# Altium schematic sheet adruino_io.SchDoc(adruino_io)
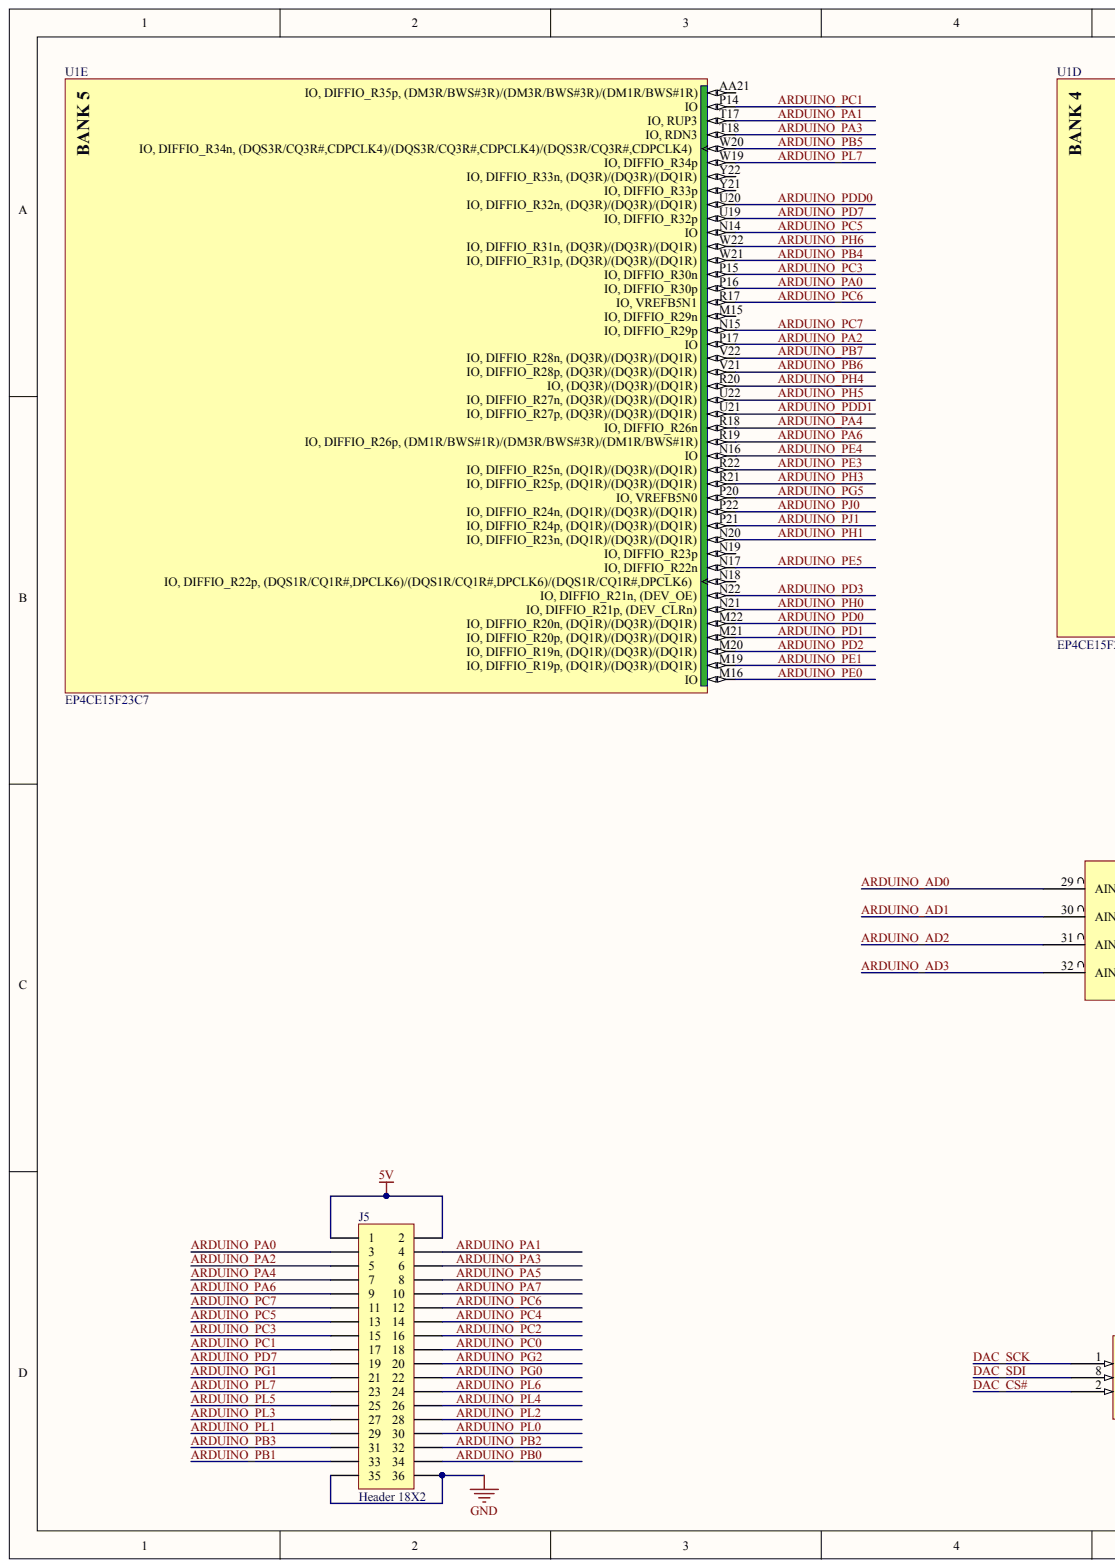
[diagram p0: adruino_io.SchDoc, left]
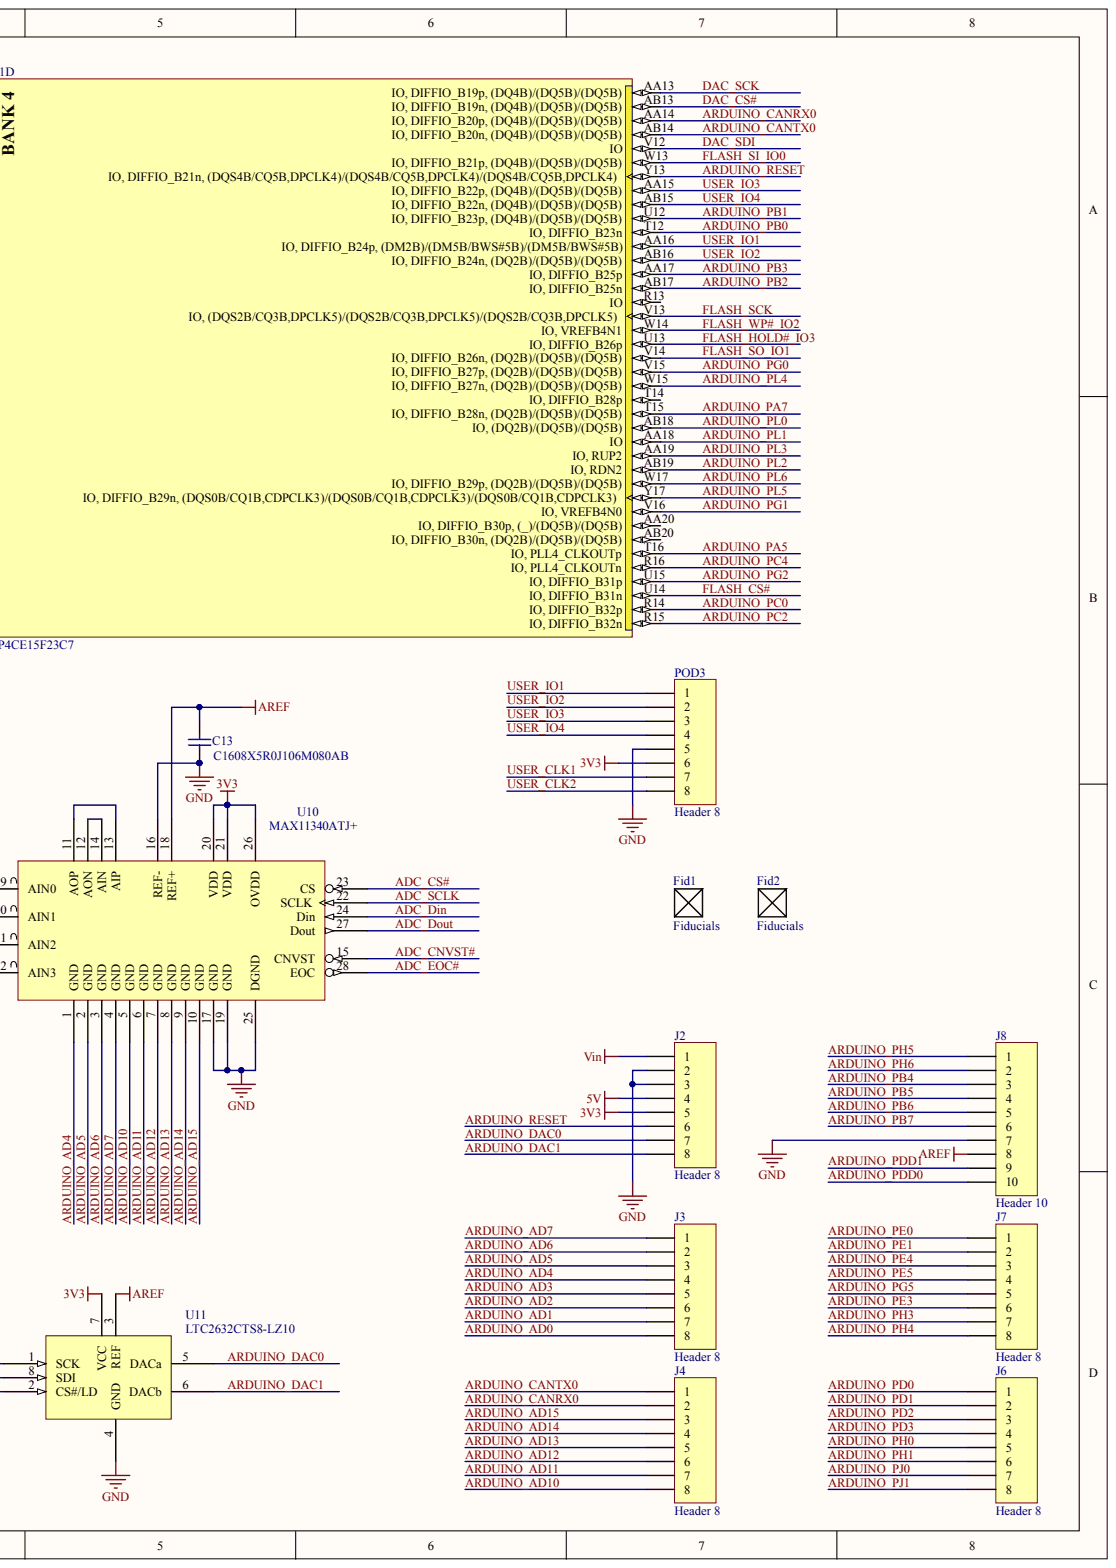
[diagram p1: adruino_io.SchDoc, right]

## Parts
C13  C1608X5R0J106M080AB  [CAPC1608X80]
Fid1  Fiducials  [Feducial]
Fid2  Fiducials  [Feducial]
J2  Header 8  [HDR1X8]
J3  Header 8  [HDR1X8]
J4  Header 8  [HDR1X8]
J5  Header 18X2  [HDR2X18]
J6  Header 8  [HDR1X8]
J7  Header 8  [HDR1X8]
J8  Header 10  [HDR1X10]
POD3  Header 8  [HDR1X8]
U1  EP4CE15F23C7  [FBGA484_A-240]  (parts D,E)
U10  MAX11340ATJ+  [TQFN_5x5_32]
U11  LTC2632CTS8-LZ10  [TSOT-23-TS8]

## Nets
3V3: J2.5 POD3.6 U10.20(VDD) U10.21(VDD) U10.26(OVDD) U11.7(VCC)
5V: J2.4 J5.1 J5.2
AREF: C13.2 J8.8 U10.18(REF+) U11.3(REF)
GND: C13.1 J2.2 J2.3 J5.35 J5.36 J8.7 POD3.5 U10.16(REF-) U10.17(GND) U10.19(GND) U10.25(DGND) U11.4(GND)
VIN: J2.1
ADC_CNVST#: U10.15(CNVST)
ADC_CS#: U10.23(CS)
ADC_DIN: U10.24(Din)
ADC_DOUT: U10.27(Dout)
ADC_EOC#: U10.28(EOC)
ADC_SCLK: U10.22(SCLK)
ARDUINO_AD0: J3.8 U10.29(AIN0)
ARDUINO_AD1: J3.7 U10.30(AIN1)
ARDUINO_AD2: J3.6 U10.31(AIN2)
ARDUINO_AD3: J3.5 U10.32(AIN3)
ARDUINO_AD4: J3.4 U10.1(GND)
ARDUINO_AD5: J3.3 U10.2(GND)
ARDUINO_AD6: J3.2 U10.3(GND)
ARDUINO_AD7: J3.1 U10.4(GND)
ARDUINO_AD10: J4.8 U10.5(GND)
ARDUINO_AD11: J4.7 U10.6(GND)
ARDUINO_AD12: J4.6 U10.7(GND)
ARDUINO_AD13: J4.5 U10.8(GND)
ARDUINO_AD14: J4.4 U10.9(GND)
ARDUINO_AD15: J4.3 U10.10(GND)
ARDUINO_CANRX0: J4.2 U1.AA14(IO,_DIFFIO_B20p,_(DQ4B)/(DQ5B)/(DQ5B))
ARDUINO_CANTX0: J4.1 U1.AB14(IO,_DIFFIO_B20n,_(DQ4B)/(DQ5B)/(DQ5B))
ARDUINO_DAC0: J2.7 U11.5(DACa)
ARDUINO_DAC1: J2.8 U11.6(DACb)
ARDUINO_PA0: J5.3 U1.P16(IO,_DIFFIO_R30p)
ARDUINO_PA1: J5.4 U1.T17(IO,_RUP3)
ARDUINO_PA2: J5.5 U1.P17(IO)
ARDUINO_PA3: J5.6 U1.T18(IO,_RDN3)
ARDUINO_PA4: J5.7 U1.R18(IO,_DIFFIO_R26n)
ARDUINO_PA5: J5.8 U1.T16(IO,_PLL4_CLKOUTp)
ARDUINO_PA6: J5.9 U1.R19(IO,_DIFFIO_R26p,_(DM1R/BWS#1R)/(DM3R/BWS#3R)/(DM1R/BWS#1R))
ARDUINO_PA7: J5.10 U1.T15(IO,_DIFFIO_B28n,_(DQ2B)/(DQ5B)/(DQ5B))
ARDUINO_PB0: J5.34 U1.T12(IO,_DIFFIO_B23n)
ARDUINO_PB1: J5.33 U1.U12(IO,_DIFFIO_B23p,_(DQ4B)/(DQ5B)/(DQ5B))
ARDUINO_PB2: J5.32 U1.AB17(IO,_DIFFIO_B25n)
ARDUINO_PB3: J5.31 U1.AA17(IO,_DIFFIO_B25p)
ARDUINO_PB4: J8.3 U1.W21(IO,_DIFFIO_R31p,_(DQ3R)/(DQ3R)/(DQ1R))
ARDUINO_PB5: J8.4 U1.W20(IO,_DIFFIO_R34n,_(DQS3R/CQ3R#,CDPCLK4)/(DQS3R/CQ3R#,CDPCLK4)/(DQS3R/CQ3R#,CDPCLK4))
ARDUINO_PB6: J8.5 U1.V21(IO,_DIFFIO_R28p,_(DQ3R)/(DQ3R)/(DQ1R))
ARDUINO_PB7: J8.6 U1.V22(IO,_DIFFIO_R28n,_(DQ3R)/(DQ3R)/(DQ1R))
ARDUINO_PC0: J5.18 U1.R14(IO,_DIFFIO_B32p)
ARDUINO_PC1: J5.17 U1.P14(IO)
ARDUINO_PC2: J5.16 U1.R15(IO,_DIFFIO_B32n)
ARDUINO_PC3: J5.15 U1.P15(IO,_DIFFIO_R30n)
ARDUINO_PC4: J5.14 U1.R16(IO,_PLL4_CLKOUTn)
ARDUINO_PC5: J5.13 U1.N14(IO)
ARDUINO_PC6: J5.12 U1.R17(IO,_VREFB5N1)
ARDUINO_PC7: J5.11 U1.N15(IO,_DIFFIO_R29p)
ARDUINO_PD0: J6.1 U1.M22(IO,_DIFFIO_R20n,_(DQ1R)/(DQ3R)/(DQ1R))
ARDUINO_PD1: J6.2 U1.M21(IO,_DIFFIO_R20p,_(DQ1R)/(DQ3R)/(DQ1R))
ARDUINO_PD2: J6.3 U1.M20(IO,_DIFFIO_R19n,_(DQ1R)/(DQ3R)/(DQ1R))
ARDUINO_PD3: J6.4 U1.N22(IO,_DIFFIO_R21n,_(DEV_OE))
ARDUINO_PD7: J5.19 U1.U19(IO,_DIFFIO_R32p)
ARDUINO_PDD0: J8.10 U1.U20(IO,_DIFFIO_R32n,_(DQ3R)/(DQ3R)/(DQ1R))
ARDUINO_PDD1: J8.9 U1.U21(IO,_DIFFIO_R27p,_(DQ3R)/(DQ3R)/(DQ1R))
ARDUINO_PE0: J7.1 U1.M16(IO)
ARDUINO_PE1: J7.2 U1.M19(IO,_DIFFIO_R19p,_(DQ1R)/(DQ3R)/(DQ1R))
ARDUINO_PE3: J7.6 U1.R22(IO,_DIFFIO_R25n,_(DQ1R)/(DQ3R)/(DQ1R))
ARDUINO_PE4: J7.3 U1.N16(IO)
ARDUINO_PE5: J7.4 U1.N17(IO,_DIFFIO_R22n)
ARDUINO_PG0: J5.22 U1.V15(IO,_DIFFIO_B27p,_(DQ2B)/(DQ5B)/(DQ5B))
ARDUINO_PG1: J5.21 U1.V16(IO,_VREFB4N0)
ARDUINO_PG2: J5.20 U1.U15(IO,_DIFFIO_B31p)
ARDUINO_PG5: J7.5 U1.P20(IO,_VREFB5N0)
ARDUINO_PH0: J6.5 U1.N21(IO,_DIFFIO_R21p,_(DEV_CLRn))
ARDUINO_PH1: J6.6 U1.N20(IO,_DIFFIO_R23n,_(DQ1R)/(DQ3R)/(DQ1R))
ARDUINO_PH3: J7.7 U1.R21(IO,_DIFFIO_R25p,_(DQ1R)/(DQ3R)/(DQ1R))
ARDUINO_PH4: J7.8 U1.R20(IO,_(DQ3R)/(DQ3R)/(DQ1R))
ARDUINO_PH5: J8.1 U1.U22(IO,_DIFFIO_R27n,_(DQ3R)/(DQ3R)/(DQ1R))
ARDUINO_PH6: J8.2 U1.W22(IO,_DIFFIO_R31n,_(DQ3R)/(DQ3R)/(DQ1R))
ARDUINO_PJ0: J6.7 U1.P22(IO,_DIFFIO_R24n,_(DQ1R)/(DQ3R)/(DQ1R))
ARDUINO_PJ1: J6.8 U1.P21(IO,_DIFFIO_R24p,_(DQ1R)/(DQ3R)/(DQ1R))
ARDUINO_PL0: J5.30 U1.AB18(IO,_(DQ2B)/(DQ5B)/(DQ5B))
ARDUINO_PL1: J5.29 U1.AA18(IO)
ARDUINO_PL2: J5.28 U1.AB19(IO,_RDN2)
ARDUINO_PL3: J5.27 U1.AA19(IO,_RUP2)
ARDUINO_PL4: J5.26 U1.W15(IO,_DIFFIO_B27n,_(DQ2B)/(DQ5B)/(DQ5B))
ARDUINO_PL5: J5.25 U1.Y17(IO,_DIFFIO_B29n,_(DQS0B/CQ1B,CDPCLK3)/(DQS0B/CQ1B,CDPCLK3)/(DQS0B/CQ1B,CDPCLK3))
ARDUINO_PL6: J5.24 U1.W17(IO,_DIFFIO_B29p,_(DQ2B)/(DQ5B)/(DQ5B))
ARDUINO_PL7: J5.23 U1.W19(IO,_DIFFIO_R34p)
ARDUINO_RESET: J2.6 U1.Y13(IO,_DIFFIO_B21n,_(DQS4B/CQ5B,DPCLK4)/(DQS4B/CQ5B,DPCLK4)/(DQS4B/CQ5B,DPCLK4))
DAC_CS#: U1.AB13(IO,_DIFFIO_B19n,_(DQ4B)/(DQ5B)/(DQ5B)) U11.2(CS#/LD)
DAC_SCK: U1.AA13(IO,_DIFFIO_B19p,_(DQ4B)/(DQ5B)/(DQ5B)) U11.1(SCK)
DAC_SDI: U1.V12(IO) U11.8(SDI)
FLASH_CS#: U1.U14(IO,_DIFFIO_B31n)
FLASH_HOLD#_IO3: U1.U13(IO,_DIFFIO_B26p)
FLASH_SCK: U1.V13(IO,_(DQS2B/CQ3B,DPCLK5)/(DQS2B/CQ3B,DPCLK5)/(DQS2B/CQ3B,DPCLK5))
FLASH_SI_IO0: U1.W13(IO,_DIFFIO_B21p,_(DQ4B)/(DQ5B)/(DQ5B))
FLASH_SO_IO1: U1.V14(IO,_DIFFIO_B26n,_(DQ2B)/(DQ5B)/(DQ5B))
FLASH_WP#_IO2: U1.W14(IO,_VREFB4N1)
USER_CLK1: POD3.7
USER_CLK2: POD3.8
USER_IO1: POD3.1 U1.AA16(IO,_DIFFIO_B24p,_(DM2B)/(DM5B/BWS#5B)/(DM5B/BWS#5B))
USER_IO2: POD3.2 U1.AB16(IO,_DIFFIO_B24n,_(DQ2B)/(DQ5B)/(DQ5B))
USER_IO3: POD3.3 U1.AA15(IO,_DIFFIO_B22p,_(DQ4B)/(DQ5B)/(DQ5B))
USER_IO4: POD3.4 U1.AB15(IO,_DIFFIO_B22n,_(DQ4B)/(DQ5B)/(DQ5B))
NetU10_11: U10.11(AOP) U10.13(AIP)
NetU10_12: U10.12(AON) U10.14(AIN)

Not connected: U1 AA20(IO,_DIFFIO_B30p,_(_)/(DQ5B)/(DQ5B)) AA21(IO,_DIFFIO_R35p,_(DM3R/BWS#3R)/(DM3R/BWS#3R)/(DM1R/BWS#1R)) AB20(IO,_DIFFIO_B30n,_(DQ2B)/(DQ5B)/(DQ5B)) M15(IO,_DIFFIO_R29n) N18(IO,_DIFFIO_R22p,_(DQS1R/CQ1R#,DPCLK6)/(DQS1R/CQ1R#,DPCLK6)/(DQS1R/CQ1R#,DPCLK6)) N19(IO,_DIFFIO_R23p) R13(IO) T14(IO,_DIFFIO_B28p) Y21(IO,_DIFFIO_R33p) Y22(IO,_DIFFIO_R33n,_(DQ3R)/(DQ3R)/(DQ1R))

## Library data of the parts
C13 = Cap: Capacitor; Component Kind: Standard; LatestRevisionDate: 17-Jul-2002; LatestRevisionNote: Re-released for DXP Platform.; Library Name: Miscellaneous Devices.IntLib; PackageDescription: Radial Cap, Thru-Hole; 2 Leads; 0.3 in Pin Spacing; PackageReference: RAD-0.3; Published: 8-Jun-2000; Signal Integrity: Cap; Simulation: CAP; Value: C1608X5R0J106M080AB; Publisher: Altium Limited
J2-J4 J6 J7 POD3 = Header 8: Header, 8-Pin; Component Kind: Standard; LatestRevisionDate: 17-Jul-2002; LatestRevisionNote: Re-released for DXP Platform.; Library Name: Miscellaneous Connectors.IntLib; Signal Integrity: Connector; Publisher: Altium Limited
J5 = Header 18X2: Header, 18-Pin, Dual row; Component Kind: Standard; LatestRevisionDate: 17-Jul-2002; LatestRevisionNote: Re-released for DXP Platform.; Library Name: Miscellaneous Connectors.IntLib; Signal Integrity: Connector; Publisher: Altium Limited
J8 = Header 10: Header, 10-Pin; Component Kind: Standard; LatestRevisionDate: 17-Jul-2002; LatestRevisionNote: Re-released for DXP Platform.; Library Name: Miscellaneous Connectors.IntLib; Signal Integrity: Connector; Publisher: Altium Limited
U1 = EP4CE15F23C7: Cyclone IV Family FPGA, 1.2V Core, 343 I/O Pins, 4 PLLs, 484-Pin FBGA, Speed Grade 7, Commercial Grade; Manufacturer: Altera; Code_JEDEC: MS-034-AAJ-1; Component Kind: Standard; ComponentLink1Description: Manufacturer URL; ComponentLink1URL: http://www.altera.com/; ComponentLink2Description: Handbook; ComponentLink2URL: http://www.altera.com/literature/hb/cyclone-iv/cyclone4-handbook.pdf; ComponentLink3Description: Pinout; ComponentLink3URL: http://www.altera.com/literature/lit-dp.jsp?category=cyc%204&showspreadsheet=y; ComponentLink4Description: Package Specification; ComponentLink4URL: http://www.altera.com/devicepackaging/04R00247-02.pdf; DatasheetVersion: ver1.6, Nov-2011; Library Name: Altera Cyclone IV E.IntLib; PackageDescription: 484-Pin FineLine Ball Grid Array (FBGA) - Wire Bond - A:2.40; PackageReference: FBGA484_A-240; PackageVersion: ver1.0, Jun-2011
U10 = MAX11338ATJ: Component Kind: Standard; VALUE: MAX11340ATJ+; Library Name: geckoedu.SchLib
U11 = LTC2632: Component Kind: Standard; VALUE: LTC2632CTS8-LZ10; Library Name: geckoedu.SchLib
Fid1 Fid2 = Fiducials: Component Kind: Standard; Library Name: Fiducials.SchLib
